# Altium schematic sheet BELL.SchDoc(BELL)
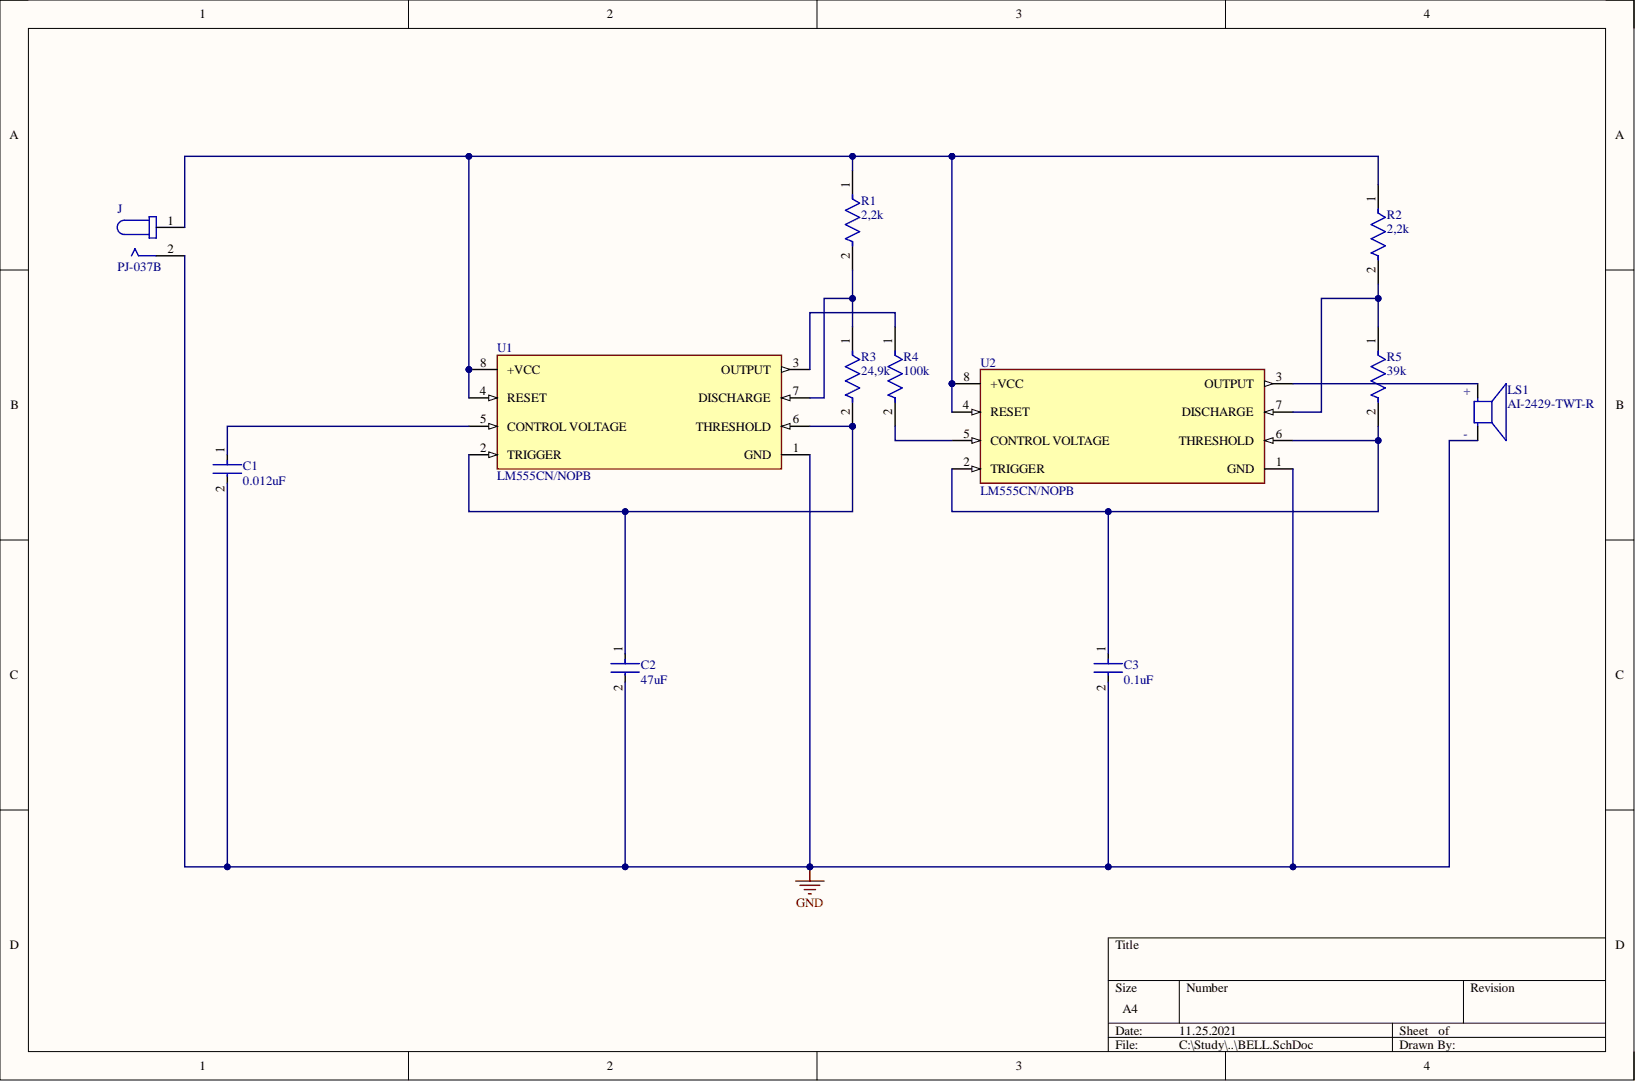
[diagram p0: BELL.SchDoc]

## Parts
C1  0.012uF  [FP-GRM033-0_03-IPC_B]
C2  47uF  [FP-MK325M-IPC_B]
C3  0.1uF  [FP-CL46-IPC_B]
J  PJ-037B  [CUI_PJ-037B]
LS1  AI-2429-TWT-R  [XDCR_AI-2429-TWT-R]
R1  2,2k  [FP-RMCF0805-IPC_B]
R2  2,2k  [FP-RMCF0805-IPC_B]
R3  24,9k  [FP-RT0805-IPC_B]
R4  100k  [FP-CRCW0805-e3-IPC_B]
R5  39k  [FP-KTR10-IPC_B]
U1  LM555CN/NOPB  [FP-P0008A-MFG]
U2  LM555CN/NOPB  [FP-P0008A-MFG]

## Nets
GND: C1.2 C2.2 C3.2 J.2 LS1.N U1.1(GND) U2.1(GND)
NetC1_1: C1.1 U1.5(CONTROL_VOLTAGE)
NetC2_1: C2.1 R3.2 U1.2(TRIGGER) U1.6(THRESHOLD)
NetC3_1: C3.1 R5.2 U2.2(TRIGGER) U2.6(THRESHOLD)
NetJ_1: J.1 R1.1 R2.1 U1.4(RESET) U1.8(+VCC) U2.4(RESET) U2.8(+VCC)
NetLS1_P: LS1.P U2.3(OUTPUT)
NetR1_2: R1.2 R3.1 U1.7(DISCHARGE)
NetR2_2: R2.2 R5.1 U2.7(DISCHARGE)
NetR4_1: R4.1 U1.3(OUTPUT)
NetR4_2: R4.2 U2.5(CONTROL_VOLTAGE)

## Library data of the parts
C1 = CMP-2010-01245-2: Chip Multilayer Ceramic Capacitors for General Purpose, 0201, 12000pF, X6S, 22%, 10%, 6.3V; Capacitance: 12nF; Case Code (Imperial): 0201; Case Code (Metric): 0603; Case/Package: 0201; Component Kind: Standard; Depth: 0.3mm; Dielectric: X6S; Height: 0.3mm; Length: 0.6mm; Max Operating Temperature: 105°C; Min Operating Temperature: -55°C; Mount: Surface Mount; Package Quantity: 15000; Packaging: Tape and Reel; Radiation Hardening: No; Termination: SMD/SMT; Thickness: 0.013inch; Tolerance: 10%; Voltage Rating: 6.3V; Voltage Rating (DC): 6.3V; Width: 0.3mm; Library Name: BELL_Schlib.SchLib
C2 = CMP-2000-06559-2: CAP CER 47UF 10V X7R 1210; Tolerance: 20%; Capacitance: 47uF; Case Code (Imperial): 1210; Case Code (Metric): 3225; Case/Package: 1210; Component Kind: Standard; Construction: Flat; Depth: 2.5mm; Dielectric: X7R; Halogen Free: Halogen Free; Height: 2.5mm; Lead Free: Lead Free; Length: 3.2mm; Max Operating Temperature: 125°C; Min Operating Temperature: -55°C; Mount: Surface Mount; Number of Pins: 2; Package Quantity: 500; Packaging: Tape and Reel; Radiation Hardening: No; Resistor Type: Ceramic; RoHS Compliant: Yes; Series: M; Termination: SMD/SMT; Thickness: 0.106inch; Voltage: 10V; Voltage Rating: 10V; Voltage Rating (DC): 10V; Width: 2.5mm; Library Name: BELL_Schlib.SchLib
C3 = CMP-13271-002143-1: Cap Ceramic 100nF 10V X5R ±10% SMD 0402 +85°C Paper T/R; Capacitance: 100nF; Case Code (Imperial): 0402; Case Code (Metric): 1005; Case/Package: 0402; Component Kind: Standard; Contact Plating: Tin; Depth: 0.5mm; Dielectric: X5R; Height: 0.5mm; Length: 1mm; Max Operating Temperature: 85°C; Min Operating Temperature: -55°C; Mount: Surface Mount; Packaging: Tape and Reel; Radiation Hardening: No; Thickness: 0.022inch; Tolerance: 10%; Voltage Rating: 10V; Width: 0.02inch; Library Name: BELL_Schlib.SchLib
J = PJ-037B: 2.5 x 6.5 mm, 2.5 A, Horizontal, Through Hole, Dc Power Jack Connector; MF: CUI Devices; Availability: Unavailable; Component Kind: Standard; CUI_purchase_URL: https://www.cuidevices.com/product/interconnect/connectors/dc-power-connectors/jacks/pj-037b?utm_source=snapeda.com&utm_medium=referral&utm_campaign=snapedaBOM; MP: PJ-037B; Package: None; Price: None; SnapEDA_Link: https://www.snapeda.com/parts/PJ-037B/CUI%20Devices/view-part/493700/?ref=snap; Library Name: BELL_Schlib.SchLib
LS1 = AI-2429-TWT-R: AUDIO INDICATOR 12VDC 8 MA 100DBA 2900HZ; MF: PUI Audio; Availability: In Stock; Check_prices: https://www.snapeda.com/parts/AI-2429-TWT-R/PUI%20Audio/view-part/439756/?ref=eda; Component Kind: Standard; MP: AI-2429-TWT-R; Package: PUI Audio; Price: None; Purchase-URL: https://pricing.snapeda.com/search/part/AI-2429-TWT-R/?ref=eda; SnapEDA_Link: https://www.snapeda.com/parts/AI-2429-TWT-R/PUI%20Audio/view-part/439756/?ref=snap; Library Name: BELL_Schlib.SchLib
R1 R2 = CMP-26527-000414-1: 2.2kΩ ±1% 0.125W 0805 Thick Film Chip Resistor AEC-Q200 compliant; Max Power Dissipation: 125mW; Resistance: 2.2kR; Temperature Coefficient: 100ppm/°C; Case/Package: 0805; Component Kind: Standard; Contact Plating: Tin; Features: Automotive AEC-Q200; Height: 0.026inch; Length: 0.079inch; Max Operating Temperature: 155°C; Min Operating Temperature: -55°C; Mount: Surface Mount; Number of Terminations: 2; Package Quantity: 10000; Packaging: Tape and Reel; Radiation Hardening: No; Resistor Type: Thick Film; RoHS Compliant: Yes; Series: RMCF; Tolerance: 1%; Width: 0.049inch; Library Name: BELL_Schlib.SchLib
R3 = CMP-03412-011084-1: RES SMD 24.9K OHM 0.1% 1/8W 0805; Manufacturer: Yageo; Manufacturer Part Number: RT0805BRD0724K9L; Power Rating: 0.125W; Resistance: 24.9KΩ; Temperature Coefficient: 25 ppm/°C; Tolerance: 0.0025; Value: 24.9KΩ; Voltage Rating: 150V; Automotive: No; Category: Res; Component Kind: Standard; ComponentLink2Description: Datasheet; ComponentLink2URL: http://www.yageo.com/documents/recent/PYu-RT_1-to-0.01_RoHS_L_9.pdf; Composition: Thin Film; Device Class L1: Passive Components; Device Class L2: Resistors; Device Class L3: Chip SMD Resistors; Digikey Description: RES SMD 24.9K OHM 0.1% 1/8W 0805; Footprint Url: http://www.yageo.com/exep/pages/download/literatures/PYu-R_Mount_10.pdf; Height: 0.6mm; Ipc Land Pattern Name: RESC200125X50; Lead Free: Yes; Mouser Description: Res Thin Film 0805 24.9K Ohm 0.1% 1/8W ±25ppm/°C Molded SMD SMD Paper T/R; Octopart: https://octopart.com/rt0805brd0724k9l-yageo-1279812; Package: RT0805; RoHS: TRUE; Series: RT; Temperature: -55°C to +155°C; Temperature Range High: +155°C; Temperature Range Low: -55°C; Library Name: BELL_Schlib.SchLib
R4 = CMP-2001-04187-2: RES Thick Film, 100kΩ, 1%, 0.125W, 100ppm/°C, 0805; Max Power Dissipation: 125mW; Resistance: 100kR; Voltage Rating: 150V; Case Code (Imperial): 0805; Case Code (Metric): 2012; Case/Package: 0805; Component Kind: Standard; Composition: Thick Film; Contact Plating: Tin; Depth: 1.25mm; Features: Automotive AEC-Q200; Height: 0.02inch; Lead Free: Lead Free; Length: 2mm; Max Operating Temperature: 155°C; Military Standard: Not; Min Operating Temperature: -55°C; Number of Pins: 2; Number of Terminations: 2; Package Quantity: 10000; Packaging: Tape and Reel; Power Rating: 125mW; Radiation Hardening: No; Temperature Coefficient: 100ppm/°C; Tolerance: 1%; Voltage Rating (DC): 150V; Weight: 0.000194oz; Width: 0.049inch; Library Name: BELL_Schlib.SchLib
R5 = CMP-08839-006009-1: Res Thick Film 0805 39kΩ 1% 0.125W ±100ppm/°C Automotive Paper T/R; Max Power Dissipation: 125mW; Resistance: 39kR; Case Code (Imperial): 0805; Case Code (Metric): 2012; Case/Package: 0805; Component Kind: Standard; Features: Automotive AEC-Q200; Height: 0.026inch; Length: 0.079inch; Max Operating Temperature: 155°C; Min Operating Temperature: -55°C; Number of Terminations: 2; Package Quantity: 5000; Packaging: Tape and Reel; Resistor Type: Thick Film; RoHS Compliant: Yes; Series: KTR; Temperature Coefficient: 100ppm/°C; Tolerance: 1%; Width: 0.049inch; Library Name: BELL_Schlib.SchLib
U1 U2 = CMP-0056-00016-4: IC OSC SINGLE TIMER 100KHZ 8-DIP; Manufacturer: Texas Instruments; Max Junction Temp: +70°C; Max Supply Voltage: 16V; Min Supply Voltage: 4.5V; Nominal Supply Current: 3mA; Number Of Timers/Counters: 1; Standoff Height: 0.51mm; Ambient Temperature Range High: +70°C; Ambient Temperature Range Low: 0°C; Automotive: No; Category: IC; Component Kind: Standard; ComponentLink2Description: Datasheet; ComponentLink2URL: http://www.ti.com/lit/ds/symlink/lm555.pdf; Device Class L1: Integrated Circuits (ICs); Device Class L2: Clock and Timing; Device Class L3: Timers and Oscillators; Digikey Description: IC OSC SINGLE TIMER 100KHZ 8-DIP; Height: 5.08mm; Lead Free: Yes; Manufacturer Part Number: LM555CN/NOPB; Octopart: https://octopart.com/lm555cn%2Fnopb-texas+instruments-24814897; Package: P0008A; RoHS: TRUE; Temperature: 0°C to +70°C; Temperature Range High: +70°C; Temperature Range Low: 0°C; Library Name: BELL_Schlib.SchLib
